# Altium schematic sheet ArduinoSensorsShield-s2.SchDoc(ArduinoSensorsShield-s2)
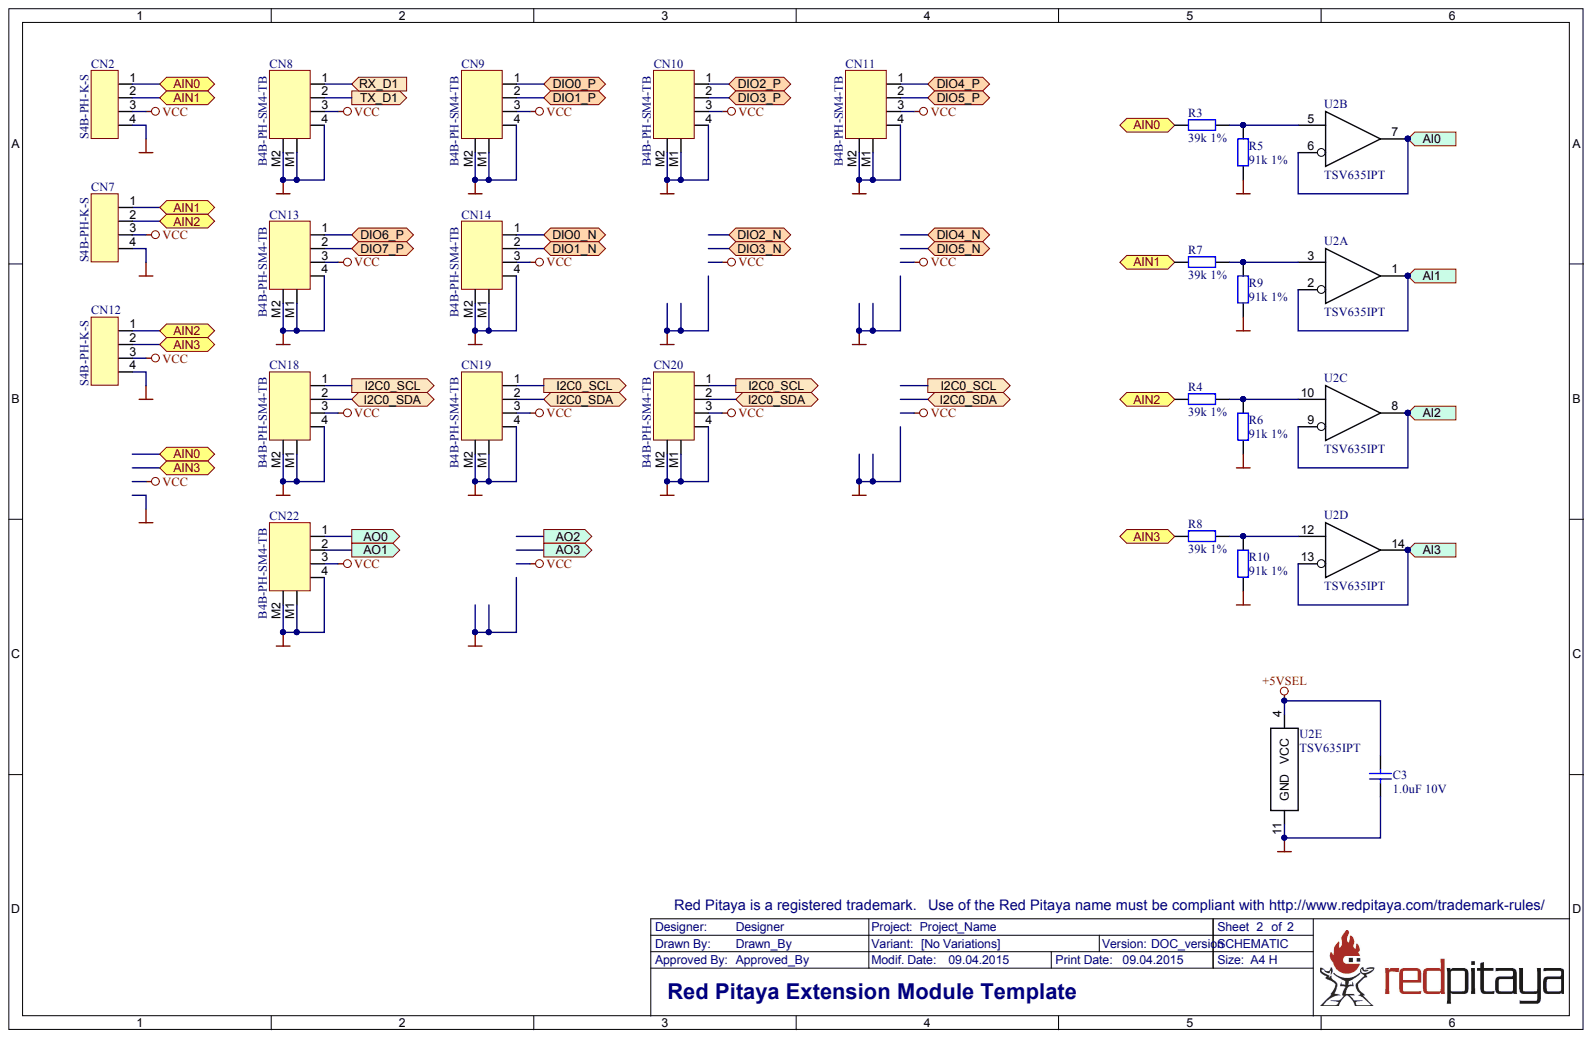
[diagram p0: ArduinoSensorsShield-s2.SchDoc]

## Parts
C3  1.0uF 10V  [CC1608-0603]
CN2  S4B-PH-K-S  [S4B-PH-K-S-RP]
CN7  S4B-PH-K-S  [S4B-PH-K-S-RP]
CN8  B4B-PH-SM4-TB  [B4B-PH-SM4-TB]
CN9  B4B-PH-SM4-TB  [B4B-PH-SM4-TB]
CN10  B4B-PH-SM4-TB  [B4B-PH-SM4-TB]
CN11  B4B-PH-SM4-TB  [B4B-PH-SM4-TB]
CN12  S4B-PH-K-S  [S4B-PH-K-S-RP]
CN13  B4B-PH-SM4-TB  [B4B-PH-SM4-TB]
CN14  B4B-PH-SM4-TB  [B4B-PH-SM4-TB]
CN18  B4B-PH-SM4-TB  [B4B-PH-SM4-TB]
CN19  B4B-PH-SM4-TB  [B4B-PH-SM4-TB]
CN20  B4B-PH-SM4-TB  [B4B-PH-SM4-TB]
CN22  B4B-PH-SM4-TB  [B4B-PH-SM4-TB]
R3  39k 1%  [CR1608-0603]
R4  39k 1%  [CR1608-0603]
R5  91k 1%  [CR1608-0603]
R6  91k 1%  [CR1608-0603]
R7  39k 1%  [CR1608-0603]
R8  39k 1%  [CR1608-0603]
R9  91k 1%  [CR1608-0603]
R10  91k 1%  [CR1608-0603]
U2  TSV635IPT  [TSOP65P640-14AL]  (parts A,B,C,D,E)

## Ports
AI0, AI1, AI2, AI3, AIN0, AIN1, AIN2, AIN3, AO0, AO1, AO2, AO3, DIO0_N, DIO0_P, DIO1_N, DIO1_P, DIO2_N, DIO2_P, DIO3_N, DIO3_P, DIO4_N, DIO4_P, DIO5_N, DIO5_P, DIO6_P, DIO7_P, I2C0_SCL, I2C0_SDA, RX_D1, TX_D1

## Nets
+5VSEL: C3.2 U2.4(VCC)
GND: C3.1 CN2.4 CN7.4 CN8.4 CN8.M1 CN8.M2 CN9.4 CN9.M1 CN9.M2 CN10.4 CN10.M1 CN10.M2 CN11.4 CN11.M1 CN11.M2 CN12.4 CN13.4 CN13.M1 CN13.M2 CN14.4 CN14.M1 CN14.M2 CN18.4 CN18.M1 CN18.M2 CN19.4 CN19.M1 CN19.M2 CN20.4 CN20.M1 CN20.M2 CN22.4 CN22.M1 CN22.M2 R5.1 R6.1 R9.1 R10.1 U2.11(GND)
VCC: CN2.3 CN7.3 CN8.3 CN9.3 CN10.3 CN11.3 CN12.3 CN13.3 CN14.3 CN18.3 CN19.3 CN20.3 CN22.3
AI0: U2.6 U2.7 ; port AI0
AI1: U2.1 U2.2 ; port AI1
AI2: U2.8 U2.9 ; port AI2
AI3: U2.13 U2.14 ; port AI3
AIN0: CN2.1 R3.1 ; port AIN0
AIN1: CN2.2 CN7.1 R7.1 ; port AIN1
AIN2: CN7.2 CN12.1 R4.1 ; port AIN2
AIN3: CN12.2 R8.1 ; port AIN3
AO0: CN22.1 ; port AO0
AO1: CN22.2 ; port AO1
DIO0_N: CN14.1 ; port DIO0_N
DIO0_P: CN9.1 ; port DIO0_P
DIO1_N: CN14.2 ; port DIO1_N
DIO1_P: CN9.2 ; port DIO1_P
DIO2_P: CN10.1 ; port DIO2_P
DIO3_P: CN10.2 ; port DIO3_P
DIO4_P: CN11.1 ; port DIO4_P
DIO5_P: CN11.2 ; port DIO5_P
DIO6_P: CN13.1 ; port DIO6_P
DIO7_P: CN13.2 ; port DIO7_P
I2C0_SCL: CN18.1 CN19.1 CN20.1 ; port I2C0_SCL
I2C0_SDA: CN18.2 CN19.2 CN20.2 ; port I2C0_SDA
RX_D1: CN8.1 ; port RX_D1
TX_D1: CN8.2 ; port TX_D1
NetR3_2: R3.2 R5.2 U2.5
NetR4_2: R4.2 R6.2 U2.10
NetR7_2: R7.2 R9.2 U2.3
NetR8_2: R8.2 R10.2 U2.12
N00075: ; port DIO5_N
N00076: ; port DIO4_N
N00077: ; port DIO3_N
N00078: ; port DIO2_N
N00079: ; port AO3
N00080: ; port AO2

## Library data of the parts
C3 = Cap: Capacitor; Component Kind: Standard; Library Name: Main_Schlib.SchLib
CN2 CN7 CN12 = CONN-1x4pin: Connector 1x4; Component Kind: Standard; Farnell: 9492488; Library Name: Main_Schlib.SchLib
CN8-CN11 CN13 CN14 CN18-CN20 CN22 = CONN-1x4pin w/mnt: Connector 1x4; Component Kind: Standard; Farnell: 9491929; Library Name: Main_Schlib.SchLib
R3-R10 = Res: Resistor; Component Kind: Standard; Library Name: Main_Schlib.SchLib
U2 = OPAMP x4: Component Kind: Standard; Farnell: 1737222; Library Name: Main_Schlib.SchLib
